# Altium schematic sheet UART TO USB.SchDoc
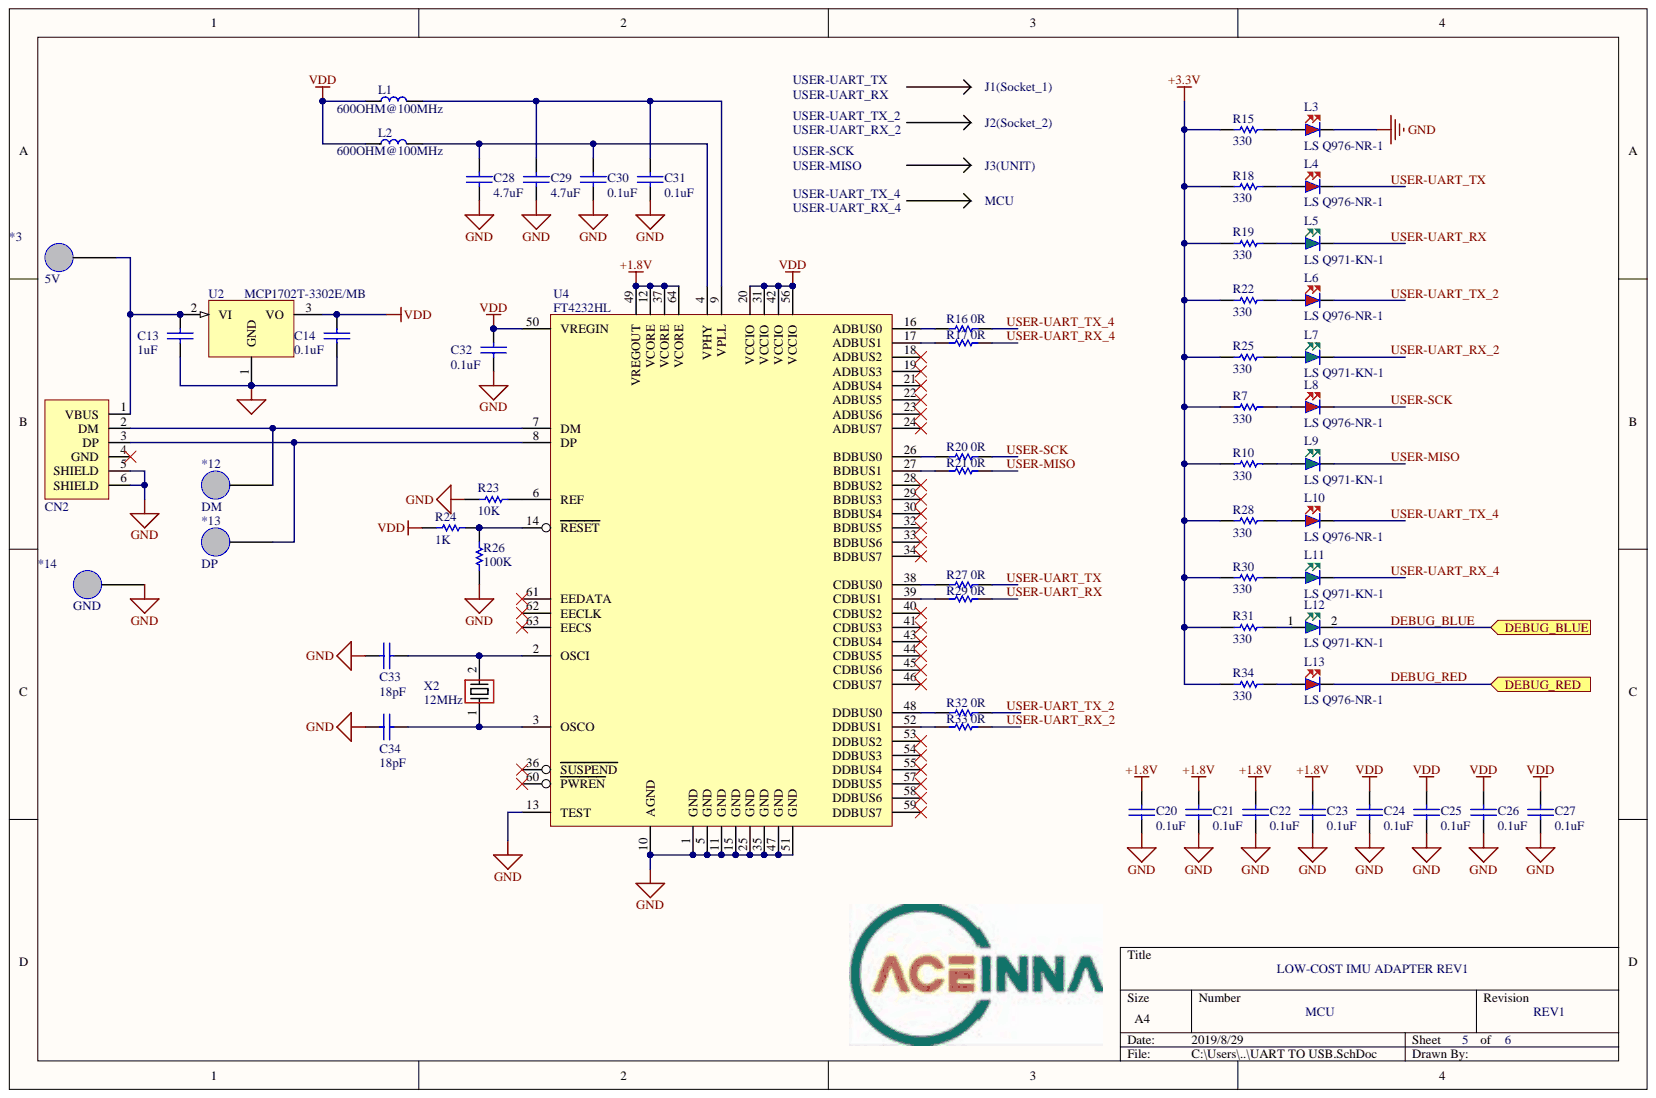
[diagram p0: UART TO USB.SchDoc]

## Parts
*3  5V  [PAD-1.5]
*12  DM  [PAD-1.5]
*13  DP  [PAD-1.5]
*14  GND  [PAD-1.5]
C13  1uF  [LC-0603_C]
C14  0.1uF  [LC-0402_C]
C20  0.1uF  [LC-0402_C]
C21  0.1uF  [LC-0402_C]
C22  0.1uF  [LC-0402_C]
C23  0.1uF  [LC-0402_C]
C24  0.1uF  [LC-0402_C]
C25  0.1uF  [LC-0402_C]
C26  0.1uF  [LC-0402_C]
C27  0.1uF  [LC-0402_C]
C28  4.7uF  [LC-0603_C]
C29  4.7uF  [LC-0603_C]
C30  0.1uF  [LC-0402_C]
C31  0.1uF  [LC-0402_C]
C32  0.1uF  [LC-0402_C]
C33  18pF  [LC-0402_C]
C34  18pF  [LC-0402_C]
CN2  CON-USB-4  [Micro-USB_629105150521]  (value not drawn)
L1  600OHM@100MHz  [LC-0603_L]
L2  600OHM@100MHz  [LC-0603_L]
L3  PRIMARY MPN: LS Q976-NR-1  [LC-0603_LED_S1]  (hidden comment: PWR)
L4  PRIMARY MPN: LS Q976-NR-1  [LC-0603_LED_S1]  (hidden comment: SOC1-TX)
L5  PRIMARY MPN: LS Q971-KN-1  [LC-0603_LED_S1]  (hidden comment: SOC1-RX)
L6  PRIMARY MPN: LS Q976-NR-1  [LC-0603_LED_S1]  (hidden comment: SOC2-TX)
L7  PRIMARY MPN: LS Q971-KN-1  [LC-0603_LED_S1]  (hidden comment: SOC2-RX)
L8  PRIMARY MPN: LS Q976-NR-1  [LC-0603_LED_S1]  (hidden comment: UNIT-TX)
L9  PRIMARY MPN: LS Q971-KN-1  [LC-0603_LED_S1]  (hidden comment: UNIT-RX)
L10  PRIMARY MPN: LS Q976-NR-1  [LC-0603_LED_S1]  (hidden comment: MCU-TX)
L11  PRIMARY MPN: LS Q971-KN-1  [LC-0603_LED_S1]  (hidden comment: MCU-RX)
L12  DEBUG_BLUE  PRIMARY MPN: LS Q971-KN-1  [LC-0603_LED_S1]
L13  DEBUG_RED  PRIMARY MPN: LS Q976-NR-1  [LC-0603_LED_S1]
R7  330  [LC-0603_R]
R10  330  [LC-0603_R]
R15  330  [LC-0603_R]
R16  0R  [LC-0402_R]
R17  0R  [LC-0402_R]
R18  330  [LC-0603_R]
R19  330  [LC-0603_R]
R20  0R  [LC-0402_R]
R21  0R  [LC-0402_R]
R22  330  [LC-0603_R]
R23  10K  [LC-0402_R]
R24  1K  [LC-0402_R]
R25  330  [LC-0603_R]
R26  100K  [LC-0402_R]
R27  0R  [LC-0402_R]
R28  330  [LC-0603_R]
R29  0R  [LC-0402_R]
R30  330  [LC-0603_R]
R31  330  [LC-0603_R]
R32  0R  [LC-0402_R]
R33  0R  [LC-0402_R]
R34  330  [LC-0603_R]
U2  MCP1702T-3302E/MB  [LC-SOT-89(SOT-89-3)]
U4  FT4232HL  [LC-TQFP-64_10x10x05P]
X2  12MHz  [LC-SMD-5032_2P]

## Ports
DEBUG_BLUE, DEBUG_RED

## Nets
+1.8V: C20.2 C21.2 C22.2 C23.2 U4.12(VCORE) U4.37(VCORE) U4.49(VREGOUT) U4.64(VCORE)
+3.3V: R7.2 R10.2 R15.2 R18.2 R19.2 R22.2 R25.2 R28.2 R30.2 R31.2 R34.2
GND: *14.1 C13.1 C14.1 C20.1 C21.1 C22.1 C23.1 C24.1 C25.1 C26.1 C27.1 C28.1 C29.1 C30.1 C31.1 C32.1 C33.1 C34.1 CN2.5(SHIELD) CN2.6(SHIELD) L3.2 R23.2 R26.2 U2.1 U4.1(GND) U4.5(GND) U4.10(AGND) U4.11(GND) U4.13(TEST) U4.15(GND) U4.25(GND) U4.35(GND) U4.47(GND) U4.51(GND)
VDD: C14.2 C24.2 C25.2 C26.2 C27.2 C32.2 L1.1 L2.1 R24.1 U2.3 U4.20(VCCIO) U4.31(VCCIO) U4.42(VCCIO) U4.50(VREGIN) U4.56(VCCIO)
DEBUG_BLUE: L12.2 ; port DEBUG_BLUE
DEBUG_RED: L13.2 ; port DEBUG_RED
USER-MISO: L9.2 R21.2
USER-SCK: L8.2 R20.2
USER-UART_RX: L5.2 R29.2
USER-UART_RX_2: L7.2 R33.2
USER-UART_RX_4: L11.2 R17.2
USER-UART_TX: L4.2 R27.2
USER-UART_TX_2: L6.2 R32.2
USER-UART_TX_4: L10.2 R16.2
Net*3_1: *3.1 C13.2 CN2.1(VBUS) U2.2
Net*12_1: *12.1 CN2.2(DM) U4.7(DM)
Net*13_1: *13.1 CN2.3(DP) U4.8(DP)
NetC28_2: C28.2 C30.2 L2.2 U4.4(VPHY)
NetC29_2: C29.2 C31.2 L1.2 U4.9(VPLL)
NetC33_2: C33.2 U4.2(OSCI) X2.2
NetC34_2: C34.2 U4.3(OSCO) X2.1
NetL3_1: L3.1 R15.1
NetL4_1: L4.1 R18.1
NetL5_1: L5.1 R19.1
NetL6_1: L6.1 R22.1
NetL7_1: L7.1 R25.1
NetL8_1: L8.1 R7.1
NetL9_1: L9.1 R10.1
NetL10_1: L10.1 R28.1
NetL11_1: L11.1 R30.1
NetL12_1: L12.1 R31.1
NetL13_1: L13.1 R34.1
NetR16_1: R16.1 U4.16(ADBUS0)
NetR17_1: R17.1 U4.17(ADBUS1)
NetR20_1: R20.1 U4.26(BDBUS0)
NetR21_1: R21.1 U4.27(BDBUS1)
NetR23_1: R23.1 U4.6(REF)
NetR24_2: R24.2 R26.1 U4.14(RESET)
NetR27_1: R27.1 U4.38(CDBUS0)
NetR29_1: R29.1 U4.39(CDBUS1)
NetR32_1: R32.1 U4.48(DDBUS0)
NetR33_1: R33.1 U4.52(DDBUS1)

Not connected: CN2 4(GND); U4 18(ADBUS2) 19(ADBUS3) 21(ADBUS4) 22(ADBUS5) 23(ADBUS6) 24(ADBUS7) 28(BDBUS2) 29(BDBUS3) 30(BDBUS4) 32(BDBUS5) 33(BDBUS6) 34(BDBUS7) 36(SUSPEND) 40(CDBUS2) 41(CDBUS3) 43(CDBUS4) 44(CDBUS5) 45(CDBUS6) 46(CDBUS7) 53(DDBUS2) 54(DDBUS3) 55(DDBUS4) 57(DDBUS5) 58(DDBUS6) 59(DDBUS7) 60(PWREN) 61(EEDATA) 62(EECLK) 63(EECS)

## Library data of the parts
*3 *12-*14 = PAD: Component Kind: Standard; Library Name: General.SchLib
C13 C14 C20-C22 C24-C27 C30-C32 = CAP SMD 0603: SCAP, CER, 0.1uF, 25V, 10%, 0603, -40℃~125℃, RoHS; PRIMARY MANUFACTURER: YAGEO; PRIMARY MPN: CC0603KRX7R8BB104; Component Kind: Standard; PRICE: 0.002$@1K; Library Name: MEMSIC.SchLib
C23 = CAP SMD 0603: SCAP, CER, 3.3uF, 25V, 10%, 0603, -40℃~125℃, RoHS; PRIMARY MANUFACTURER: YAGEO; PRIMARY MPN: CC0603KRX7R8BB335; Component Kind: Standard; PRICE: 0.002$@1K; Library Name: MEMSIC.SchLib
C28 C29 = CAP SMD 0603: SCAP, CER, 4.7uF, 25V, 10%, 0603, -40℃~125℃, RoHS; PRIMARY MANUFACTURER: YAGEO; PRIMARY MPN: CC0603KRX7R8BB475; Component Kind: Standard; PRICE: 0.002$@1K; Library Name: MEMSIC.SchLib
C33 C34 = CAP SMD 0603: SCAP, CER, 18pF, 25V, 10%, 0603, -40℃~125℃, RoHS; PRIMARY MANUFACTURER: YAGEO; PRIMARY MPN: CC0603FRNPO8BN18; Component Kind: Standard; PRICE: 0.002$@1K; Library Name: MEMSIC.SchLib
CN2 = CON-USB-4: CONN, USB, 4P, STRAIGHT, RoHS; PRIMARY MANUFACTURER: LEOCO; PRIMARY MPN: 2075S0K042; Component Kind: Standard; Library Name: MEMSIC.SchLib
L1 L2 = IND SMD 0603: SIND, FERRITE, 600OHM@100MHz, ±25%, 1AIR, 0.2OHM DCR, 0603, -55°C ~ 125°C, RoHS; PRIMARY MANUFACTURER: WE; PRIMARY MPN: 742792651; Component Kind: Standard; PRICE: 0.1$@1K; Library Name: MEMSIC.SchLib
L3 L4 L6 L8 L10 L13 = LED-0603-RED: LED, RED,  VF, mA IF, MCD, 0603, -40℃~85℃, RoHS; Component Kind: Standard; Library Name: Multi-Function IB_1.SCHLIB
L5 L7 L9 L11 L12 = LED-0603-GREEN: LED, GREEN,  VF, mA IF, MCD, 0603, -40℃~85℃, RoHS; Component Kind: Standard; Library Name: MEMSIC.SchLib
R7 R10 R16-R34 = RES7: RES,330 OHM,1/16W,5%,0402; PRIMARY MANUFACTURER: PANASONIC; PRIMARY MPN: ERJ-3GEY0R00V; PN: 4040-0003-01; Component Kind: Standard; Library Name: 电阻.SchLib
R15 = RES8: RES,1K OHM,1/16W,5%,0402; PRIMARY MANUFACTURER: PANASONIC; PRIMARY MPN: ERJ-3GEY0R00V; PN: 4040-0003-01; Component Kind: Standard; Library Name: 电阻.SchLib
U2 = 78xx: Linear Regulator; Component Kind: Standard; Library Name: General.SchLib
U4 = FT4232HL: IC, USB to UART, 4CH HS UART@1CH USB, LQFP64, -40°C ~ 85°C, RoHS; PRIMARY MANUFACTURER: FTDI CHIP; Component Kind: Standard; PRICE: 4.8$@1K; PRIMARY MPN: FT4232HL; Library Name: MEMSIC.SchLib
X2 = ABM3-12.000MHz-18pF-K4STD: XTAL, CRYSTAL, 12.000 MHz, ± 30 ppm, ±50ppm, SMD 2PAD, -40°C ~ +125°C, RoHS; PRIMARY MANUFACTURER: ABRACON; Component Kind: Standard; PRICE: 1$@1K; PRIMARY MPN: ABM3-12.000MHz-18pF-K4STD; Library Name: MEMSIC.SchLib
